# Altium schematic sheet 8-Other_Inputs.SchDoc(8-Other_Inputs)
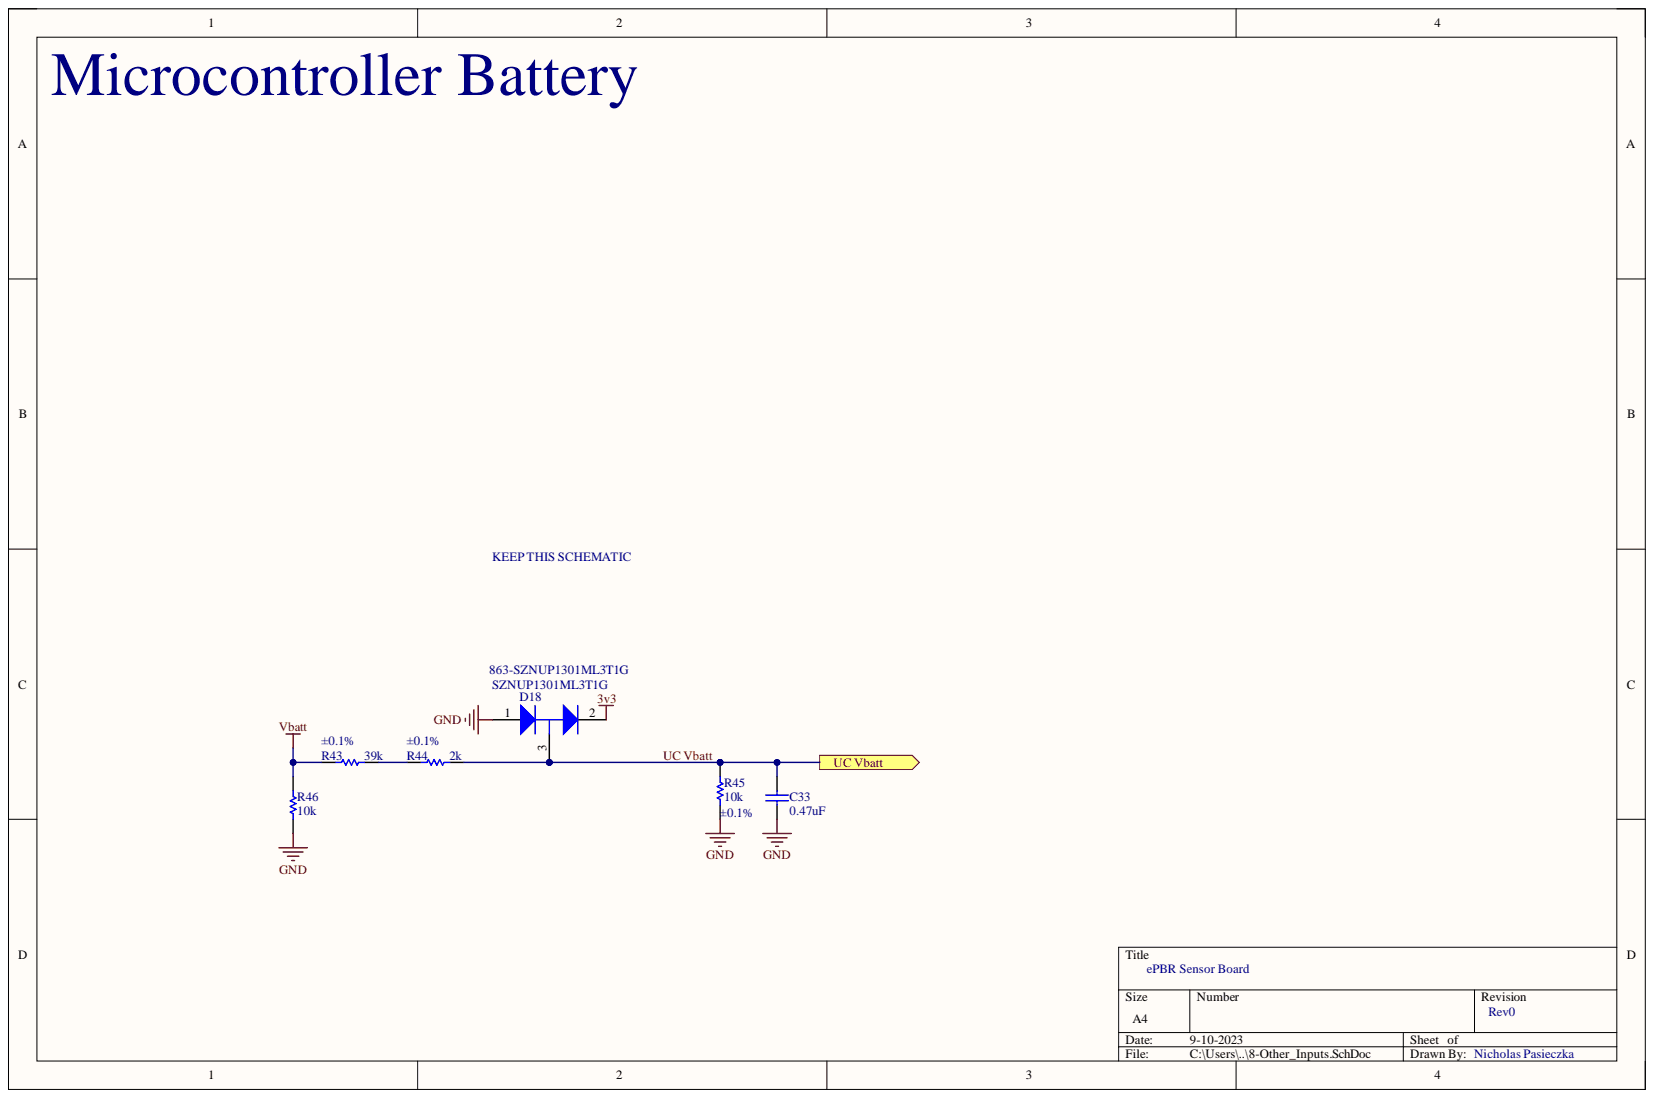
[diagram p0: 8-Other_Inputs.SchDoc]

## Parts
C33  value 0.47uF  [1608[0603]]  (hidden comment: Cap)
D18  SZNUP1301ML3T1G  [ONSC-SOT-23-3-318-08_V]
R43  value 39k  Resistance (Ohms): 39k  Tolerance: ±0.1%  [1608[0603]]  (hidden comment: Res1)
R44  value 2k  Resistance (Ohms): 2k  Tolerance: ±0.1%  [1608[0603]]  (hidden comment: Res1)
R45  value 10k  Resistance (Ohms): 10k  Tolerance: ±0.1%  [1608[0603]]  (hidden comment: Res1)
R46  value 10k  Resistance (Ohms): 10k  [1608[0603]]  (hidden comment: Res1)

## Ports
UC Vbatt

## Nets
3v3: D18.2
GND: C33.1 D18.1 R45.1 R46.1
Vbatt: R43.1 R46.2
UC Vbatt: C33.2 D18.3 R44.2 R45.2 ; port UC Vbatt
NetR43_2: R43.2 R44.1

## Library data of the parts
C33 = Cap: Capacitor; Manufacturer: Yageo; Manufacturer Part Number: CC0603KRX7R8BB474; Capacitance: 0.47µF; Temperature Coefficient: X7R; Tolerance: ±10%; Voltage - Rated: 25V; Applications: General Purpose; Category: Capacitors; Component Kind: Standard; ComponentLink1Description: http://www.yageo.com/documents/recent/UPY-GPHC_X7R_6.3V-to-50V_16.pdf; ComponentLink1URL: http://www.yageo.com/documents/recent/UPY-GPHC_X7R_6.3V-to-50V_16.pdf; Failure Rate: -; Features: -; Height - Seated (Max): -; Lead Spacing: -; Lead Style: -; Library Name: Miscellaneous Devices.IntLib; Mounting Type: Surface Mount, MLCC; Operating Temperature: -55°C ~ 125°C; Package / Case: 0603 (1608 Metric); Packaging: Cut Tape (CT); Part Status: Active; Pricing 1: 1=0.22, 10=0.158, 25=0.1156, 50=0.0898, 100=0.0745, 250=0.06168, 500=0.05226, 1000=0.04324 (CAD); Ratings: -; RoHS: RoHS Compliant; Simulation: CAP; Size / Dimension: 0.063" L x 0.031" W (1.60mm x 0.80mm); Stock 1: 11076; Supplier 1: Digi-Key; Supplier Part Number 1: 311-1805-1-ND; Thickness (Max): 0.035" (0.90mm)
D18 = CMP-1063-00171-1: Low Capacitance Diode Array for ESD Protection in a Single Data Line, 3-Pin SOT-23, Pb-Free, Tape and Reel; Manufacturer: On Semiconductor; C Max (pF): 0.9; Direction: Bidirectional; Number of Lines: 1; V(BR) Min (V): 70; Component Kind: Standard; ComponentLink1Description: Manufacturer URL; ComponentLink1URL: http://www.onsemi.com/; ComponentLink2Description: Datasheet; ComponentLink2URL: http://www.onsemi.cn/pub_link/Collateral/NUP1301ML3T1-D.PDF; ComponentLink3Description: Package Specification; ComponentLink3URL: http://www.onsemi.cn/pub_link/Collateral/318-08.PDF; DatasheetVersion: Rev. 6; Library Name: Altium Content Vault; Mounting Technology: Surface Mount; PackageDescription: 3-Pin Small Outline Transistor, Body 2.92 x 1.3 mm, Pitch 0.95 mm; PackageReference: SOT-23-3-318-08; PackageVersion: Rev. AP, 11/2009; Packing: Tape and Reel; PartNumber: SZNUP1301ML3T1G; RoHS: Pb-Free; Supplier 1: Mouser; Supplier Part Number 1: 863-SZNUP1301ML3T1G; VRWM Max (V): 70
R43 = Res1: Resistor; Manufacturer: Yageo; Manufacturer Part Number: RT0603BRD0739KL; Power (Watts): 0.1W, 1/10W; Temperature Coefficient: ±25ppm/°C; Category: Resistors; Component Kind: Standard; ComponentLink1Description: http://www.yageo.com/documents/recent/PYu-RT_1-to-0.05_RoHS_L_6.pdf; ComponentLink1URL: http://www.yageo.com/documents/recent/PYu-RT_1-to-0.05_RoHS_L_6.pdf; Composition: Thin Film; Failure Rate: -; Features: Moisture Resistant; Height - Seated (Max): 0.022" (0.55mm); Library Name: Miscellaneous Devices.IntLib; Number of Terminations: 2; Operating Temperature: -55°C ~ 155°C; Package / Case: 0603 (1608 Metric); Packaging: Cut Tape (CT); Part Status: Active; Pricing 1: 1=0.55, 10=0.464, 25=0.3376, 50=0.2446, 100=0.1814, 250=0.1392, 500=0.10966, 1000=0.07592 (CAD); RoHS: RoHS Compliant; Simulation: RESISTOR; Size / Dimension: 0.063" L x 0.031" W (1.60mm x 0.80mm); Stock 1: 2740; Supplier 1: Digi-Key; Supplier Device Package: 0603; Supplier Part Number 1: YAG1649CT-ND
R44 = Res1: Resistor; Manufacturer: Yageo; Manufacturer Part Number: AT0603BRD072KL; Power (Watts): 0.1W, 1/10W; Temperature Coefficient: ±25ppm/°C; Category: Resistors; Component Kind: Standard; ComponentLink1Description: http://www.yageo.com/documents/recent/PYu-AT_51_RoHS_L-3.pdf; ComponentLink1URL: http://www.yageo.com/documents/recent/PYu-AT_51_RoHS_L-3.pdf; Composition: Thin Film; Failure Rate: -; Features: Anti-Sulfur, Automotive AEC-Q200, Moisture Resistant; Height - Seated (Max): 0.022" (0.55mm); Library Name: Miscellaneous Devices.IntLib; Number of Terminations: 2; Operating Temperature: -55°C ~ 155°C; Package / Case: 0603 (1608 Metric); Packaging: Cut Tape (CT); Part Status: Active; Pricing 1: 1=0.59, 10=0.431, 25=0.3188, 50=0.235, 100=0.1725, 250=0.14248, 500=0.11746, 1000=0.08498 (CAD); RoHS: RoHS Compliant; Simulation: RESISTOR; Size / Dimension: 0.063" L x 0.032" W (1.60mm x 0.80mm); Stock 1: 1218; Supplier 1: Digi-Key; Supplier Device Package: 0603; Supplier Part Number 1: YAG2112CT-ND
R45 = Res1: Resistor; Manufacturer: Yageo; Manufacturer Part Number: RT0603BRD0710KL; Power (Watts): 0.1W, 1/10W; Temperature Coefficient: ±25ppm/°C; Category: Resistors; Component Kind: Standard; ComponentLink1Description: http://www.yageo.com/documents/recent/PYu-RT_1-to-0.05_RoHS_L_6.pdf; ComponentLink1URL: http://www.yageo.com/documents/recent/PYu-RT_1-to-0.05_RoHS_L_6.pdf; Composition: Thin Film; Failure Rate: -; Features: Moisture Resistant; Height - Seated (Max): 0.022" (0.55mm); Library Name: Miscellaneous Devices.IntLib; Number of Terminations: 2; Operating Temperature: -55°C ~ 155°C; Package / Case: 0603 (1608 Metric); Packaging: Cut Tape (CT); Part Status: Active; Pricing 1: 1=0.5, 10=0.42, 25=0.3048, 50=0.2212, 100=0.164, 250=0.12592, 500=0.0992, 1000=0.06867 (CAD); RoHS: RoHS Compliant; Simulation: RESISTOR; Size / Dimension: 0.063" L x 0.031" W (1.60mm x 0.80mm); Stock 1: 2958; Supplier 1: Digi-Key; Supplier Device Package: 0603; Supplier Part Number 1: YAG1236CT-ND
R46 = Res1: Resistor; Manufacturer: Yageo; Manufacturer Part Number: RC0603JR-0710KL; Power (Watts): 0.1W, 1/10W; Temperature Coefficient: ±100ppm/°C; Tolerance: ±5%; Category: Resistors; Component Kind: Standard; ComponentLink1Description: http://www.yageo.com/NewPortal/yageodocoutput?fileName=/pdf/R-Chip/PYu-RC_Group_51_RoHS_L_4.pdf; ComponentLink1URL: http://www.yageo.com/NewPortal/yageodocoutput?fileName=/pdf/R-Chip/PYu-RC_Group_51_RoHS_L_4.pdf; Composition: Thick Film; Failure Rate: -; Features: Moisture Resistant; Height - Seated (Max): 0.022" (0.55mm); Library Name: Miscellaneous Devices.IntLib; Number of Terminations: 2; Operating Temperature: -55°C ~ 155°C; Package / Case: 0603 (1608 Metric); Packaging: Cut Tape (CT); Part Status: Active; Pricing 1: 1=0.16, 10=0.016, 25=0.0112, 50=0.0084, 100=0.0062, 250=0.0048, 500=0.00382, 1000=0.00281 (CAD); RoHS: RoHS Compliant; Simulation: RESISTOR; Size / Dimension: 0.063" L x 0.031" W (1.60mm x 0.80mm); Stock 1: 2936292; Supplier 1: Digi-Key; Supplier Device Package: 0603; Supplier Part Number 1: 311-10KGRCT-ND
